# Altium schematic sheet FishTalk_Schematic_1.SchDoc
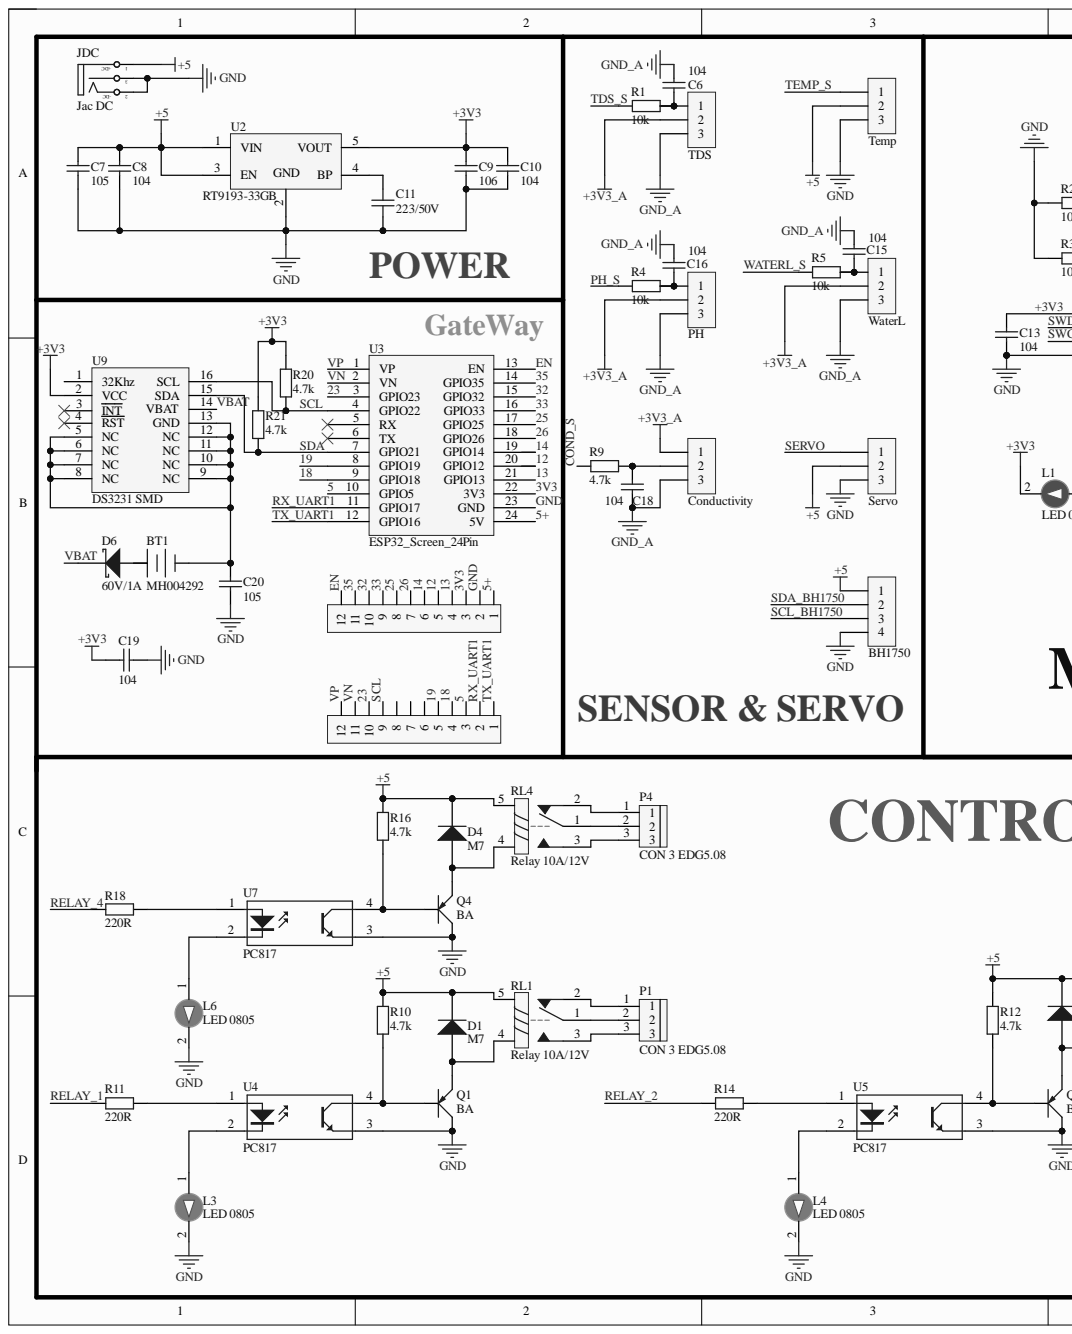
[diagram p0: FishTalk_Schematic_1.SchDoc, left]
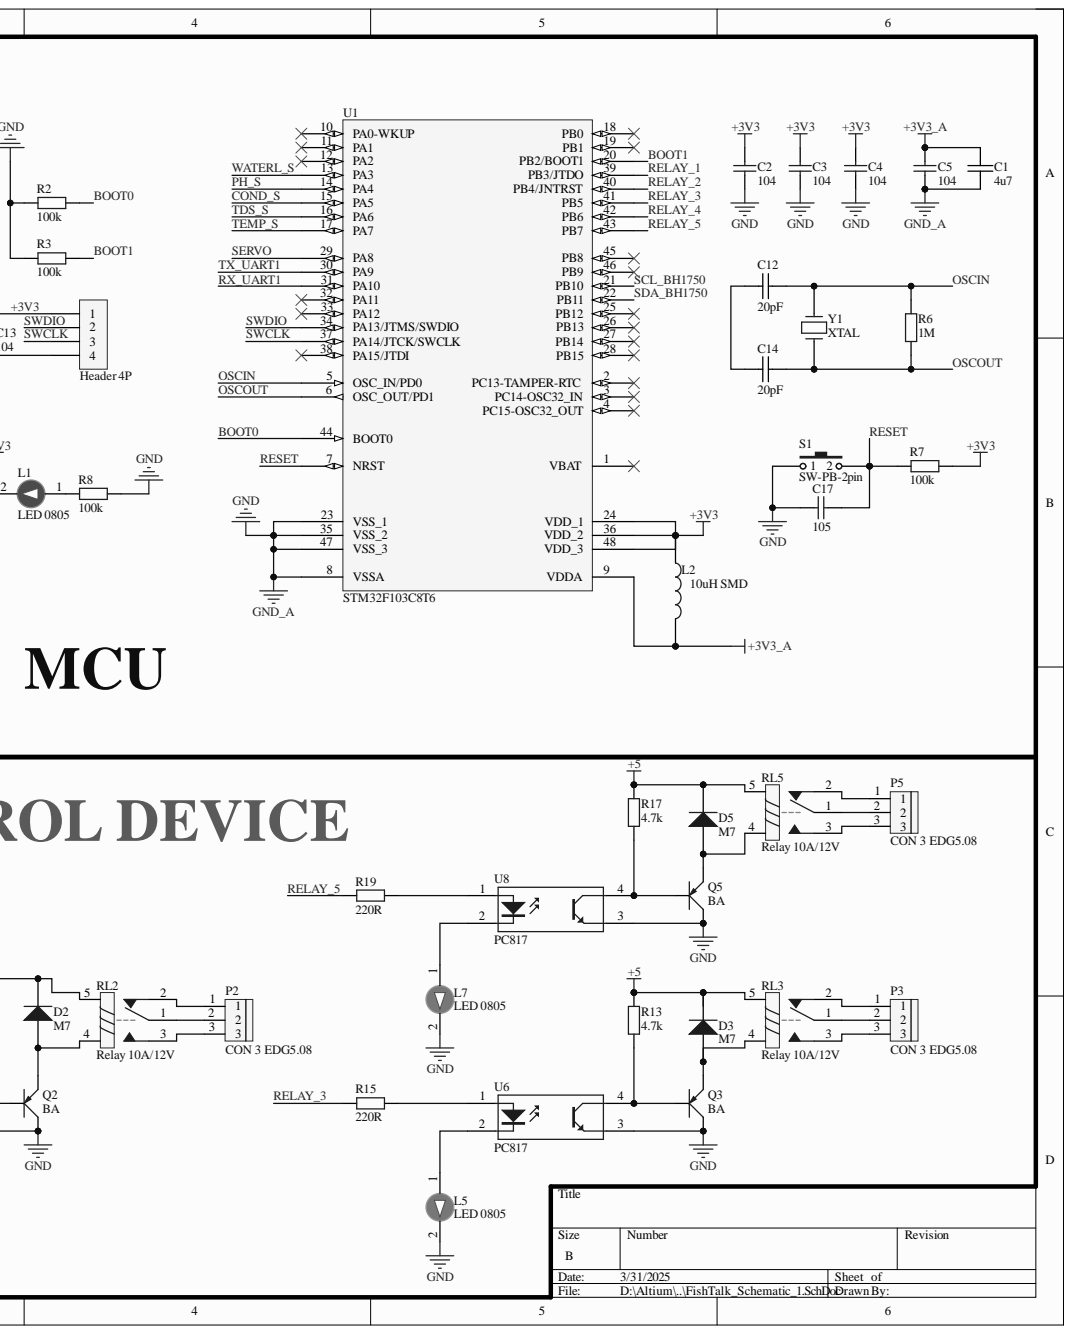
[diagram p1: FishTalk_Schematic_1.SchDoc, right]

## Parts
BT1  MH004292  [DE PINCR1220]
C1  4u7  [0603C-GENERIC]
C2  104  [tu 0603]
C3  104  [tu 0603]
C4  104  [tu 0603]
C5  104  [tu 0603]
C6  104  [tu 0603]
C7  105  [tu 0805]
C8  104  [tu 0603]
C9  106  [tu 0805]
C10  104  [tu 0603]
C11  223/50V  [CAPC-0603 (1608)]
C12  20pF  [0603C-GENERIC]
C13  104  [tu 0603]
C14  20pF  [0603C-GENERIC]
C15  104  [tu 0603]
C16  104  [tu 0603]
C17  105  [tu 0805]
C18  104  [tu 0603]
C19  104  [tu 0603]
C20  105  [tu 0805]
D1  M7  [DIODE SMA]
D2  M7  [DIODE SMA]
D3  M7  [DIODE SMA]
D4  M7  [DIODE SMA]
D5  M7  [DIODE SMA]
D6  60V/1A  [DIODE_DO214AC_SMA]
H1  TDS  [XH2.54M-3]
H2  Temp  [XH2.54M-3]
H3  Header 4P  [XH2.54M-4]
H4  PH  [XH2.54M-3]
H5  WaterL  [XH2.54M-3]
H6  Conductivity  [XH2.54M-3]
H7  Servo  [XH2.54M-3]
H8  Header 12P  [XH2.54M-12]  (value not drawn)
H9  Header 12P  [XH2.54M-12]  (value not drawn)
H10  BH1750  [XH2.54M-4]
JDC  Jac DC  [DC-IN]
L1  LED 0805  [LED-1206-Red]
L2  10uH SMD  [Cuon Cam 7x7x5]
L3  LED 0805  [LED-1206-Green]
L4  LED 0805  [LED-1206-Green]
L5  LED 0805  [LED-1206-Green]
L6  LED 0805  [LED-1206-Green]
L7  LED 0805  [LED-1206-Green]
P1  CON 3 EDG5.08  [Con 3 KF301 5.08]
P2  CON 3 EDG5.08  [Con 3 KF301 5.08]
P3  CON 3 EDG5.08  [Con 3 KF301 5.08]
P4  CON 3 EDG5.08  [Con 3 KF301 5.08]
P5  CON 3 EDG5.08  [Con 3 KF301 5.08]
Q1  BA  [SOT23]
Q2  BA  [SOT23]
Q3  BA  [SOT23]
Q4  BA  [SOT23]
Q5  BA  [SOT23]
R1  10k  [tro smd 0603]
R2  100k  [tro smd 0603]
R3  100k  [tro smd 0603]
R4  10k  [tro smd 0603]
R5  10k  [tro smd 0603]
R6  1M  [tro smd 0603]
R7  100k  [tro smd 0603]
R8  100k  [tro smd 0603]
R9  4.7k  [tro smd 0603]
R10  4.7k  [tro smd 0603]
R11  220R  [tro smd 0603]
R12  4.7k  [tro smd 0603]
R13  4.7k  [tro smd 0603]
R14  220R  [tro smd 0603]
R15  220R  [tro smd 0603]
R16  4.7k  [tro smd 0603]
R17  4.7k  [tro smd 0603]
R18  220R  [tro smd 0603]
R19  220R  [tro smd 0603]
R20  4.7k  [tro smd 0603]
R21  4.7k  [tro smd 0603]
RL1  Relay 10A/12V  [RL_SPDT_10A]
RL2  Relay 10A/12V  [RL_SPDT_10A]
RL3  Relay 10A/12V  [RL_SPDT_10A]
RL4  Relay 10A/12V  [RL_SPDT_10A]
RL5  Relay 10A/12V  [RL_SPDT_10A]
S1  SW-PB-2pin  [SW_B3U-1000P]
U1  STM32F103C8T6  [LQFP48_L]
U2  RT9193-33GB  [SOT23-5AL]
U3  ESP32_Screen_24Pin  [ESP32_Module_Screen_24Pin]
U4  PC817  [PhotoCoupler_SMD]
U5  PC817  [PhotoCoupler_SMD]
U6  PC817  [PhotoCoupler_SMD]
U7  PC817  [PhotoCoupler_SMD]
U8  PC817  [PhotoCoupler_SMD]
U9  DS3231 SMD  [SOIC 16]
Y1  XTAL  [XTAL]

## Nets
3V3: C1.1 C2.1 C3.1 C4.1 C5.1 C9.1 C10.1 C13.1 C19.1 H1.2 H3.1 H4.2 H5.2 H6.1 H9.3 L1.2 L2.1 L2.2 R7.2 R20.2 R21.2 U1.9(VDDA) U1.24(VDD_1) U1.36(VDD_2) U1.48(VDD_3) U2.5(VOUT) U3.22(3V3) U9.2(VCC)  [also labelled +3V3]
5: C7.1 C8.1 D1.2 D2.2 D3.2 D4.2 D5.2 H2.2 H7.2 H8.3 H10.1 JDC.1 R10.2 R12.2 R13.2 R16.2 R17.2 RL1.5 RL2.5 RL3.5 RL4.5 RL5.5 U2.1(VIN) U2.3(EN) U3.10(GPIO5)
5+: H9.1 U3.24(5V)
12: H9.5 U3.20(GPIO12)
13: H9.4 U3.21(GPIO13)
14: H9.6 U3.19(GPIO14)
18: H8.4 U3.9(GPIO18)
19: H8.5 U3.8(GPIO19)
23: H8.10 U3.3(GPIO23)
25: H9.8 U3.17(GPIO25)
26: H9.7 U3.18(GPIO26)
32: H9.10 U3.15(GPIO32)
33: H9.9 U3.16(GPIO33)
35: H9.11 U3.14(GPIO35)
BOOT0: R2.2 U1.44(BOOT0)
BOOT1: R3.2 U1.20(PB2/BOOT1)
COND_S: R9.1 U1.15(PA5)
EN: H9.12 U3.13(EN)
GND: C1.2 C2.2 C3.2 C4.2 C5.2 C6.1 C7.2 C8.2 C9.2 C10.2 C11.1 C13.2 C15.1 C16.1 C17.1 C18.2 C19.2 C20.2 H1.3 H2.3 H3.4 H4.3 H5.3 H6.3 H7.3 H9.2 H10.4 JDC.2 JDC.3 L3.2 L4.2 L5.2 L6.2 L7.2 Q1.3 Q2.3 Q3.3 Q4.3 Q5.3 R2.1 R3.1 R8.2 S1.1 U1.8(VSSA) U1.23(VSS_1) U1.35(VSS_2) U1.47(VSS_3) U2.2 U3.23(GND) U4.3 U5.3 U6.3 U7.3 U8.3
OSCIN: C12.2 R6.2 U1.5(OSC_IN/PD0) Y1.2
OSCOUT: C14.2 R6.1 U1.6(OSC_OUT/PD1) Y1.1
PH_S: R4.1 U1.14(PA4)
RELAY_1: R11.1 U1.39(PB3/JTDO)
RELAY_2: R14.1 U1.40(PB4/JNTRST)
RELAY_3: R15.1 U1.41(PB5)
RELAY_4: R18.1 U1.42(PB6)
RELAY_5: R19.1 U1.43(PB7)
RESET: C17.2 R7.1 S1.2 U1.7(NRST)
RX_UART1: H8.2 U1.31(PA10) U3.11(GPIO17)
SCL: H8.9 R20.1 U3.4(GPIO22) U9.16(SCL)
SCL_BH1750: H10.3 U1.21(PB10)
SDA: R21.1 U3.7(GPIO21) U9.15(SDA)
SDA_BH1750: H10.2 U1.22(PB11)
SERVO: H7.1 U1.29(PA8)
SWCLK: H3.3 U1.37(PA14/JTCK/SWCLK)
SWDIO: H3.2 U1.34(PA13/JTMS/SWDIO)
TDS_S: R1.1 U1.16(PA6)
TEMP_S: H2.1 U1.17(PA7)
TX_UART1: H8.1 U1.30(PA9) U3.12(GPIO16)
VBAT: D6.2 U9.14(VBAT)
VN: H8.11 U3.2(VN)
VP: H8.12 U3.1(VP)
WATERL_S: R5.1 U1.13(PA3)
NetBT1_2: BT1.2 C20.1 U9.5(NC) U9.6(NC) U9.7(NC) U9.8(NC) U9.9(NC) U9.10(NC) U9.11(NC) U9.12(NC) U9.13(GND)
NetC6_2: C6.2 H1.1 R1.2
NetC11_2: C11.2 U2.4(BP)
NetC12_1: C12.1 C14.1
NetC15_2: C15.2 H5.1 R5.2
NetC16_2: C16.2 H4.1 R4.2
NetC18_1: C18.1 H6.2 R9.2
NetD1_1: D1.1 Q1.2 RL1.4
NetD2_1: D2.1 Q2.2 RL2.4
NetD3_1: D3.1 Q3.2 RL3.4
NetD4_1: D4.1 Q4.2 RL4.4
NetD5_1: D5.1 Q5.2 RL5.4
NetL1_1: L1.1 R8.1
NetL3_1: L3.1 U4.2
NetL4_1: L4.1 U5.2
NetL5_1: L5.1 U6.2
NetL6_1: L6.1 U7.2
NetL7_1: L7.1 U8.2
NetP1_1: P1.1 RL1.2
NetP1_2: P1.2 RL1.1
NetP1_3: P1.3 RL1.3
NetP2_1: P2.1 RL2.2
NetP2_2: P2.2 RL2.1
NetP2_3: P2.3 RL2.3
NetP3_1: P3.1 RL3.2
NetP3_2: P3.2 RL3.1
NetP3_3: P3.3 RL3.3
NetP4_1: P4.1 RL4.2
NetP4_2: P4.2 RL4.1
NetP4_3: P4.3 RL4.3
NetP5_1: P5.1 RL5.2
NetP5_2: P5.2 RL5.1
NetP5_3: P5.3 RL5.3
NetQ1_1: Q1.1 R10.1 U4.4
NetQ2_1: Q2.1 R12.1 U5.4
NetQ3_1: Q3.1 R13.1 U6.4
NetQ4_1: Q4.1 R16.1 U7.4
NetQ5_1: Q5.1 R17.1 U8.4
NetR11_2: R11.2 U4.1
NetR14_2: R14.2 U5.1
NetR15_2: R15.2 U6.1
NetR18_2: R18.2 U7.1
NetR19_2: R19.2 U8.1

Not connected: BT1 1; D6 1; H8 6 7 8; U1 1(VBAT) 2(PC13-TAMPER-RTC) 3(PC14-OSC32_IN) 4(PC15-OSC32_OUT) 10(PA0-WKUP) 11(PA1) 12(PA2) 18(PB0) 19(PB1) 25(PB12) 26(PB13) 27(PB14) 28(PB15) 32(PA11) 33(PA12) 38(PA15/JTDI) 45(PB8) 46(PB9); U3 5(RX) 6(TX); U9 1(32Khz) 3(INT) 4(RST)

## Library data of the parts
BT1 = De Pin 3.3V: Dán; Component Kind: Standard; LatestRevisionDate: Đế Pin CR2032 SMD003; Library Name: THU VIEN SCH.SchLib
C1 = 0603C-4U7-10V-X5R-TDK: CAPACITOR 0603 CERAMIC; Manufacturer: TDK Corporation; Manufacturer Part Number: C1608X5R1A475K; Capacitance: 4.7µF; Reel: C13; Temperature Coefficient: X5R; Tolerance: ±10%; Voltage - Rated: 10V; Category: Capacitors; Component Kind: Standard; Features: General Purpose; Lead Spacing: -; Library Name: vh_altium_library.intLib; Mounting Type: Surface Mount, MLCC; Operating Temperature: -55°C ~ 85°C; Package / Case: 0603 (1608 metric); Packaging: Tape & Reel (TR); RoHS: RoHS Compliant; Supplier: Digi-Key; Supplier 1: Digi-Key; Supplier Part Number: 445-5170-2-ND; Supplier Part Number 1: 445-5170-2-ND; Thickness: 0.80mm
C2-C6 C8 C10 C13 C15 C16 C18 C19 = Tu 0603 104 50V 10%: Dán; Don_Vi: Con; Ma_Hang: MH004568; So_Chan: 2; Ten_Linh_Kien: Tụ 0603 104-100nF 10% 50V; Chung_Loai: Dán; Component Kind: Standard; Library Name: Tu dien.SchLib
C7 C17 C20 = Tu 0805 105 50V 10%: Dán; Don_Vi: Con; Ma_Hang: MH004552; So_Chan: 2; Ten_Linh_Kien: Tụ 0805 105-1uF 10% 50V; Chung_Loai: Dán; Component Kind: Standard; Library Name: Tu dien.SchLib
C9 = Tu 0805 106 16V 10%: Dán; Don_Vi: Con; Ma_Hang: MH004553; So_Chan: 2; Ten_Linh_Kien: Tụ 0805 106-10uF 10% 16V; Chung_Loai: Dán; Component Kind: Standard; Library Name: Tu dien.SchLib
C11 = CC0603JRX7R9BB223: CAP CER 0.022uF 5% 50V X7R 0603; CompanyID: VT-00673; Solder: SMT; Category: CAPACITOR; Component Kind: Standard; Library Name: BYTECH_HW_LIB_2019 (1).IntLib; MPN: CC0603JRX7R9BB223; PinCount: 2; Value: 223/50V
C12 C14 = 0603C-220PF-50V-C0G-MUR: CAPACITOR 0603 CERAMIC; Manufacturer: Murata Electronics North America; Manufacturer Part Number: GRM1885C1H221JA01D; Capacitance: 220pF; Reel: C15; Temperature Coefficient: C0G, NP0; Tolerance: ±5%; Voltage - Rated: 50V; Category: Capacitors; Component Kind: Standard; Features: General Purpose; Lead Spacing: -; Library Name: vh_altium_library.intLib; Mounting Type: Surface Mount, MLCC; Operating Temperature: -55°C ~ 125°C; Package / Case: 0603 (1608 metric); Packaging: Tape & Reel (TR); RoHS: RoHS Compliant; Supplier: Digi-Key; Supplier 1: Digi-Key; Supplier Part Number: 490-1435-2-ND; Supplier Part Number 1: 490-1435-2-ND; Thickness: 0.80mm
D1-D5 = Diode M7 ( 4007 ): Dán; Don_Vi: Con; Ma_Hang: MH000944; Ten_Linh_Kien: Diode M7 1N4007 SMD 1A 1000V; Chung_Loai: Dán; Component Kind: Standard; Library Name: Diode.SchLib
D6 = B160-13-F: DIODE SCHOTTKY 60V 1A SMA DO-214A; CompanyID: VT-00897; Solder: SMT; Component Kind: Standard; Library Name: BYTECH_HW_LIB_2019 (1).IntLib; MPN: B160-13-F; Value: 60V/1A
H1 H2 H4-H7 = Header 3P 2.54 Duc Thang: Cắm; Don_Vi: Con; Ma_Hang: MH001292; Ten_Linh_Kien: Header 3P XH2.54-3P Đực Thẳng; Chung_Loai: Cắm; Component Kind: Standard; Library Name: Header.SchLib
H3 H10 = Header 4P 2.54 Duc Thang: Cắm; Don_Vi: Con; Ma_Hang: MH001285; Ten_Linh_Kien: Header 4P XH2.54-4P Đực Thẳng; Chung_Loai: Cắm; Component Kind: Standard; Library Name: Header.SchLib
H8 H9 = Header 12P: Cắm; Don_Vi: Con; Ma_Hang: MH001286; Ten_Linh_Kien: Header 10P XH2.54-10P Đực Thẳng; Chung_Loai: Cắm; Component Kind: Standard; Library Name: Header.SchLib
JDC = DCIN: Jac DC input; Component Kind: Standard; Value: Jac DC; Library Name: syhaunguyen.IntLib
L1 = LED 1206 Do: Dán; Don_Vi: Con; Ma_Hang: MH002334; Ten_Linh_Kien: LED1206 Màu Đỏ (Red); Chung_Loai: Dán; Component Kind: Standard; Library Name: Led.SchLib
L2 = CUON CAM 47uH SMD: Dán; Don_Vi: Con; Ma_Hang: MH004211; Ten_Linh_Kien: Cuộn Cảm 47uH 7x7x4MM CD74R; Chung_Loai: Dán; Component Kind: Standard; Library Name: Cuon Cam.SchLib
L3-L7 = LED 1206 Xanh La: Dán; Don_Vi: Con; Ma_Hang: MH002947; Ten_Linh_Kien: LED1206 Màu Xanh Lá (Green); Chung_Loai: Dán; Component Kind: Standard; Library Name: Led.SchLib
P1-P5 = CON 3 EDG5.08: Cắm; Ma_Hang: MH000760; Ten_Linh_Kien: CON 3 2EDG5.08-3P 5.08MM ( Xanh Cong); Chung_Loai: Cắm; Component Kind: Standard; Don_Vi: Con; Library Name: Connector.SchLib
Q1-Q5 = A1015 BA SMD: PNP 600mW, ECB; Component Kind: Standard; Library Name: TranFet.SchLib
R1 R4 R5 R9 R10 R12 R13 R16 R17 R20 R21 = Tro 0603 1% 10k: Dán; Don_Vi: Con; Ma_Hang: MH006333; So_Chan: 2; Ten_Linh_Kien: Trở 0603 1% 10k; Chung_Loai: Dán; Component Kind: Standard; Library Name: Dien Tro.SchLib
R2 R3 R7 R8 = Tro 0603 5% 100k: Dán; Don_Vi: Con; Ma_Hang: MH006314; So_Chan: 2; Ten_Linh_Kien: Trở 0603 5% 100k; Chung_Loai: Dán; Component Kind: Standard; Library Name: Dien Tro.SchLib
R6 = Tro 0603 5% 1M: Dán; Don_Vi: Con; Ma_Hang: MH006909; So_Chan: 2; Ten_Linh_Kien: Trở 0603 5% 1M; Chung_Loai: Dán; Component Kind: Standard; Library Name: Dien Tro.SchLib
R11 R14 R15 R18 R19 = Tro 0603 5% 220R: Dán; Don_Vi: Con; Ma_Hang: MH006322; So_Chan: 2; Ten_Linh_Kien: Trở 0603 5% 220R; Chung_Loai: Dán; Component Kind: Standard; Library Name: Dien Tro.SchLib
RL1-RL5 = Relay 1: Relay SPDT,10A - 12VDC 5 Pin; Value: Relay SPDT, 10A, 12V; Component Kind: Standard; Library Name: syhaunguyen.IntLib
S1 = SW-PB-2pin: Switch; Component Kind: Standard; LatestRevisionDate: 17-Jul-2002; LatestRevisionNote: Re-released for DXP Platform.; PackageReference: SPST-2; Published: 24-Mar-1999; Library Name: thuvien_altium.SchLib; Publisher: Altium Limited
U1 = STM32F103C8T6: STM32 ARM-based 32-bit MCU with 64 Kbytes Flash, 48-pin LQFP, Industrial Temperature; Component Kind: Standard; ComponentLink1Description: Manufacturer Link; ComponentLink1URL: www.st.com/stm32; ComponentLink2Description: Datasheet; ComponentLink2URL: http://www.st.com/stonline/products/literature/ds/13587.pdf; DatasheetVersion: rev6, Mar-2008; PackageDescription: 48-Pin Low-Profile Quad Flat Pack, 7 x 7 mm Body, 0.5 mm Pitch (LQFP48); PackageReference: LQFP48; PackageVersion: Mar-2008; Published: 30-May-2008; Library Name: MinhTien_SCHLib.SchLib; Publisher: Altium Limited
U2 = RT9193: 1A Regulator; Component Kind: Standard; Library Name: MinhTien_SCHLib.SchLib
U4-U8 = PC817: 4-Pin Phototransistor Optocoupler; Component Kind: Standard; DatasheetVersion: Nov-2003; LatestRevisionDate: 04-Mar-2005; LatestRevisionNote: Sch pins 3 & 4 Swapped.; PackageReference: DIP-4; Published: 24-Mar-1999; Library Name: MinhTien_SCHLib.SchLib; Publisher: Altium Limited
U9 = DS3231 SMD: SOIC; Component Kind: Standard; Library Name: IC.SchLib
Y1 = XTAL: Crystal; Component Kind: Standard; Library Name: iDr.ALTIUM.IntLib
U3 = ESP32_Screen_24Pin: Component Kind: Standard; Library Name: Schlib1_fist_tank.SchLib
